# Altium schematic sheet FSK-Helper900.SchDoc
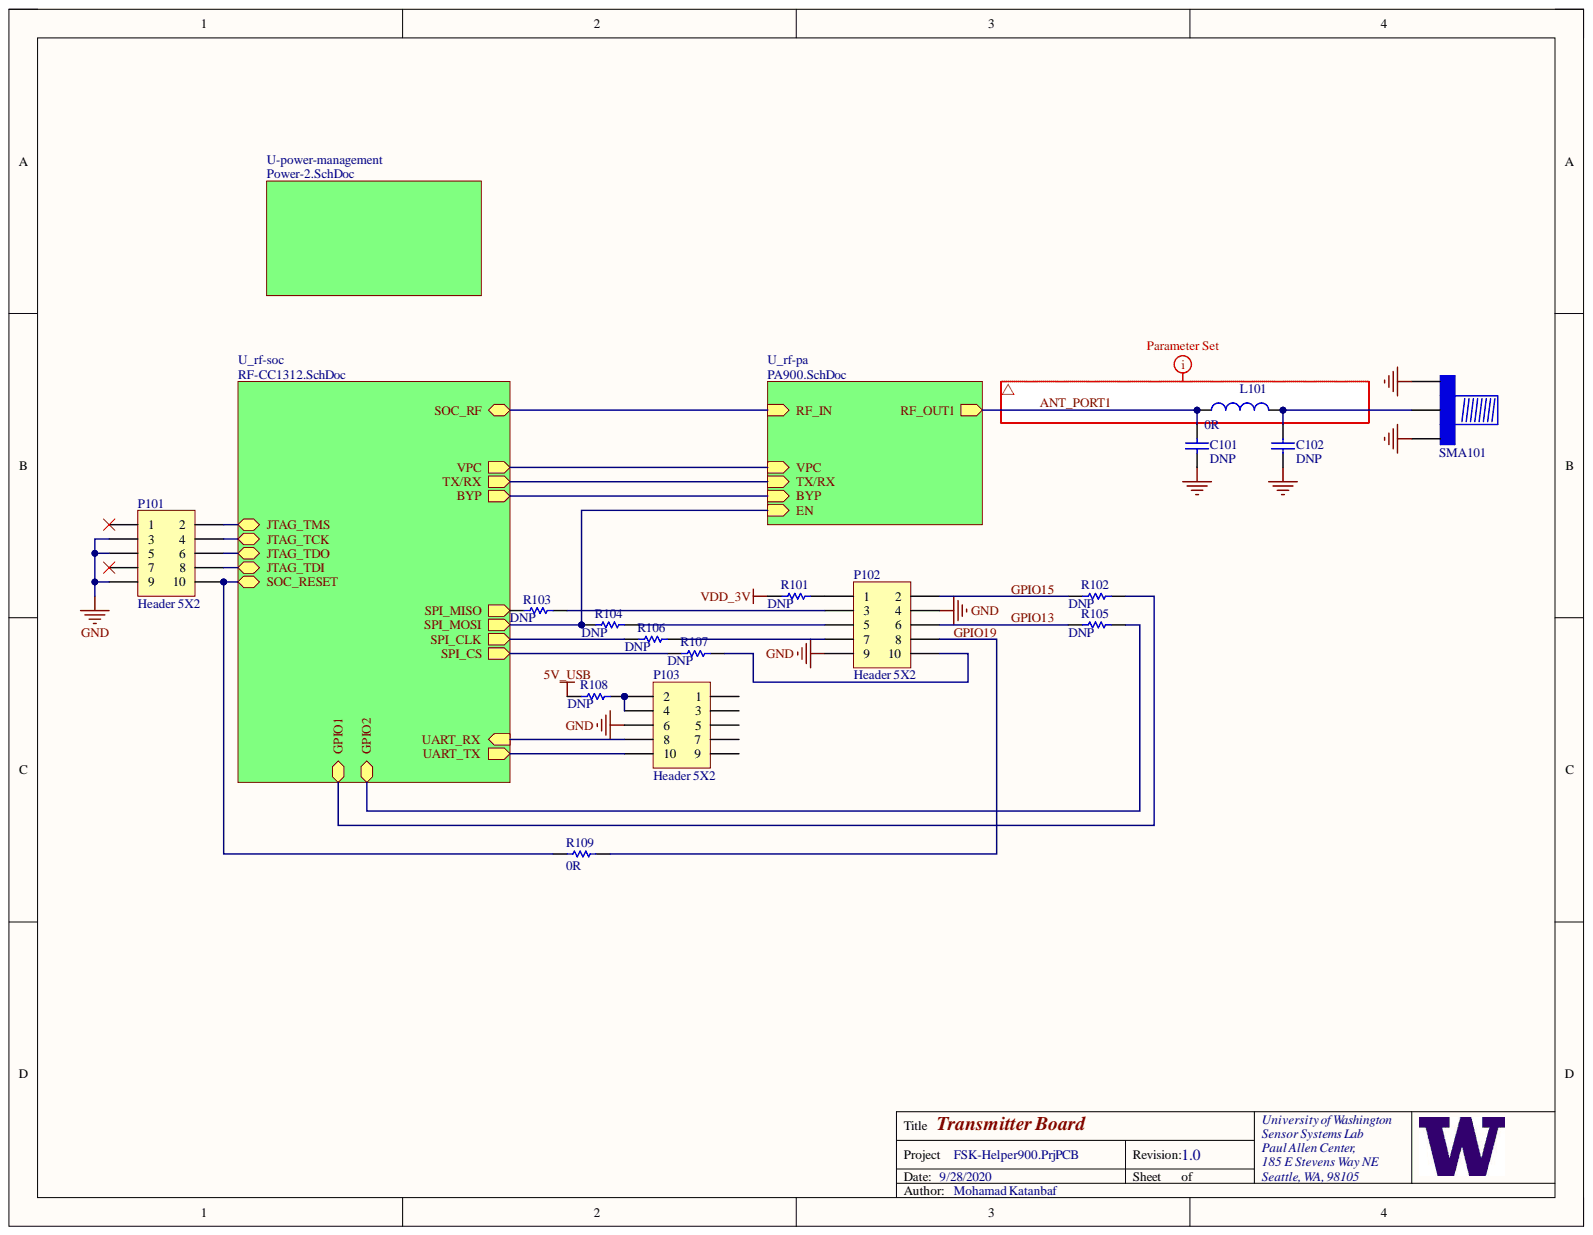
[diagram p0: FSK-Helper900.SchDoc]

## Parts
C101  DNP  [0603]
C102  DNP  [0603]
L101  0R  [0603]
P101  Header 5X2  [FTSH-105-XX-X-DV-K]
P102  Header 5X2  [HDR2X5]
P103  Header 5X2  [HDR2X5]
R101  DNP  [0402]
R102  DNP  [0402]
R103  DNP  [0402]
R104  DNP  [0402]
R105  DNP  [0402]
R106  DNP  [0402]
R107  DNP  [0402]
R108  DNP  [0603]
R109  0R  [0402]
SMA101  SMA - Edge  [SMA - Edge - Narrow]  (value not drawn)

## Nets
5V_USB: R108.2
GND: C101.1 C102.1 P101.3 P101.5 P101.9 P102.4 P102.9 P103.6 SMA101.1
VDD_3V: R101.2
ANT_PORT1: C101.2 L101.1
GPIO13: P102.6 R105.2
GPIO15: P102.2 R102.2
GPIO19: P102.8 R109.1
NetC102_2: C102.2 L101.2 SMA101.2
NetP101_1: P101.1
NetP101_2: P101.2
NetP101_4: P101.4
NetP101_6: P101.6
NetP101_7: P101.7
NetP101_8: P101.8
NetP101_10: P101.10 R109.2
NetP102_1: P102.1 R101.1
NetP102_3: P102.3 R103.1
NetP102_5: P102.5 R104.1
NetP102_7: P102.7 R106.1
NetP102_10: P102.10 R107.1
NetP103_1: P103.1
NetP103_2: P103.2 P103.4 R108.1
NetP103_3: P103.3
NetP103_5: P103.5
NetP103_7: P103.7
NetP103_8: P103.8
NetP103_9: P103.9
NetP103_10: P103.10
NetR102_1: R102.1
NetR103_2: R103.2
NetR104_2: R104.2
NetR105_1: R105.1
NetR106_2: R106.2
NetR107_2: R107.2
BYP:
RF_IN:
TX/RX:
VPC:

## Library data of the parts
C101 C102 = Cap: Ceramic Capacitor (Surface Mount); Component Kind: Standard; Value: DNP; Library Name: WISP_AD10.SchLib
L101 = Ind: Inductor (Surface Mount); Manufacturer: Yageo; Manufacturer Part Number: RC0603JR-070RL; Note: RES SMD 0 OHM JUMPER 1/10W 0603; Rating: 1/10W; Component Kind: Standard; Digi-Key Part Number: 311-0.0GRCT-ND; Value: 0R; Library Name: WISP_AD10.SchLib
P101 = Header 5X2: Header, 5-Pin, Dual row; Manufacturer: Samtec Inc.; Manufacturer Part Number: FTSH-105-01-F-DV-K; Note: CONN HEADER 10POS DUAL .05" SMD; Component Kind: Standard; Digi-Key Part Number: SAM8796-ND; LatestRevisionDate: 17-Jul-2002; LatestRevisionNote: Re-released for DXP Platform.; Library Name: Miscellaneous Connectors.IntLib; Signal Integrity: Connector; Publisher: Altium Limited
P102 P103 = Header 5X2: Header, 5-Pin, Dual row; Manufacturer: Harwin Inc.; Manufacturer Part Number: M20-7830546; Component Kind: Standard; Digi-Key Part Number: 952-1797-ND; Library Name: Miscellaneous Connectors.IntLib; Signal Integrity: Connector
R101-R108 = Res: Chip Resistor (Surface Mount); Manufacturer: Yageo; Manufacturer Part Number: RC0402JR-070RL; Note: RES SMD 0 OHM JUMPER 1/16W 0402; Rating: 1/16W; Value: 0R; Component Kind: Standard; Digi-Key Part Number: 311-0.0JRCT-ND; Library Name: WISP_AD10.SchLib
R109 = Res: Chip Resistor (Surface Mount); Manufacturer: Yageo; Manufacturer Part Number: RC0402JR-070RL; Note: RES SMD 0 OHM JUMPER 1/16W 0402; Rating: 1/16W; Component Kind: Standard; Digi-Key Part Number: 311-0.0JRCT-ND; Value: 0R; Library Name: WISP_AD10.SchLib
SMA101 = SMA - Edge: SMA Connector Receptacle, Female Socket 50 Ohm Board Edge, End Launch Solder; Manufacturer: Linx Technologies Inc.; Manufacturer Part Number: CONSMA003.062; Note: CONN SMA RCPT STR 50OHM EDGE MNT; Component Kind: Standard; Digi-Key Part Number: CONSMA003.062-ND; Library Name: WISP_AD10.SchLib
